# Altium schematic sheet DASH.SchDoc (continued)
## Library data of the parts (continued)
U5 = MCP2551: MICROCHIP - MCP2551-I/SN - HI SPEED TRANSCEIVER CAN CMS 2551; Data Rate: 1Mbps; Max Supply Current: 75mA; Max Supply Voltage: 5.5V; Min Supply Voltage: 4.5V; Number of Drivers: 1; Operating Supply Voltage: 5V; Slew Rate: 8.5V/µs; Case/Package: SOIC; Component Kind: Standard; ComponentLink1Description: DATASHEET; ComponentLink1URL: https://html.alldatasheet.com/html-pdf/514790/MICROCHIP/MCP2561/486/1/MCP2561.html; Contact Plating: Tin,Matte; Height: 1.25mm; Lead Free: Lead Free; Length: 4.9mm; Library Name: DASH1_Schlib1.SchLib; Logic Function: Transceiver; Manufacturer 1: Microchip; Manufacturer Part Number 1: MCP2551-I/SN; Max Operating Temperature: 85°C; Min Operating Temperature: -40°C; Mount: Surface Mount; Nominal Supply Current: 75mA; Number of Pins: 8; Number of Receive Buffers: 1; Number of Receivers: 1; Number of Terminals: 8; Number of Transceivers: 1; Number of Transmit Buffers: 1; Package Quantity: 100; Packaging: Rail/Tube; Pricing 1: 1=1,02000, 25=0,85000 (USD); Radiation Hardening: No; REACH SVHC: No SVHC; RoHS: Yes; Stock 1: 18330; Supplier 1: Digi-Key; Supplier Part Number 1: MCP2551-I/SN-ND; Width: 3.9mm
U6 = REGULADOR: IC REG LDO 3.3V 1.5A D2PAK; Manufacturer 1: Analog Devices / Linear Technology; Dropout Voltage: 1.3V; Max Input Voltage: 25V; Min Input Voltage: 2.55V; Number of Outputs: 1; Operating Supply Current: 5mA; Output Type: Fixed; Polarity: Positive; Power Dissipation: 15W; Power Supply Rejection Ratio (PSRR): 72dB; Quiescent Current: 10mA; Case/Package: M; Category: Regulador; Component Kind: Standard; ComponentLink1Description: DATASHEET; ComponentLink1URL: https://datasheet.ciiva.com/2058/1722-2058517.pdf?src-supplier=Digi-Key; Height: 4.57mm; Lead Free: Contains Lead; Length: 10.54mm; Library Name: DASH1_Schlib1.SchLib; Manufacturer Part Number 1: LT1086CM-3.3; Max Operating Temperature: 150°C; Max Output Current: 1.5A; Min Current Limit: 1.5A; Min Operating Temperature: 0°C; Number of Pins: 3; Number of Regulators: 1; Output Current: 1.5A; Output Voltage: 3.3V; Package Quantity: 50; Packaging: Rail/Tube; Pricing 1: 1=11,36990, 25=7,21000, 100=5,40000, 500=4,32000, 1000=3,87000 (USD); Radiation Hardening: No; RoHS: Yes; Stock 1: 2286; Supplier 1: Arrow; Supplier Part Number 1: LT1086CM-3.3; Width: 9.4mm
X2 = Fuse 1: Fuse; Component Kind: Standard; LatestRevisionDate: 17-Jul-2002; LatestRevisionNote: Re-released for DXP Platform.; Library Name: Miscellaneous Devices.IntLib; PackageDescription: Thru-Hole; 2 Leads; PackageReference: PIN-W2/E2.8; Published: 8-Jun-2000; Simulation: FUSE; Publisher: Altium Limited
CRYSTAL = CRYSTAL: Component Kind: Standard; Library Name: DASH1_Schlib1.SchLib
X1 = FUSE 3A: Component Kind: Standard; Library Name: DASH1_Schlib1.SchLib
